# Altium schematic sheet Sheet3.SchDoc
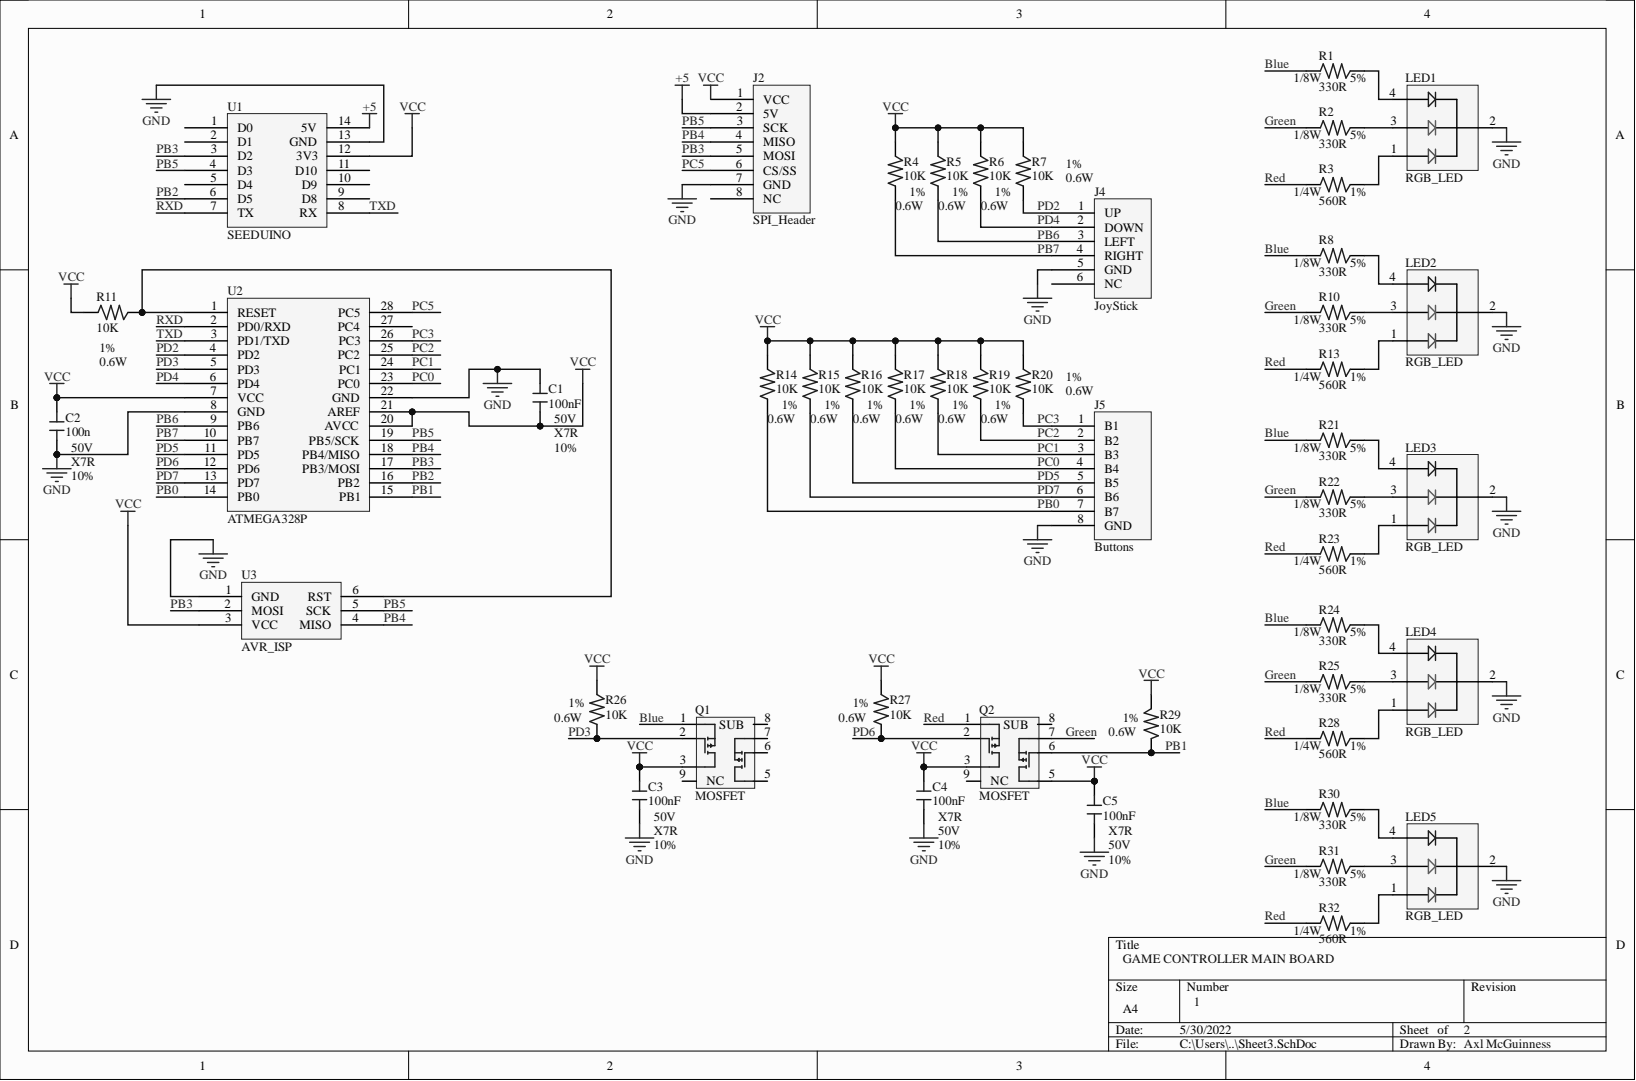
[diagram p0: Sheet3.SchDoc]

## Parts
C1  100nF  Parameter 1: 10%  Parameter 2: 50V  Parameter 3: X7R  [Capacitor]
C2  100n  Parameter 1: 10%  Parameter 2: 50V  Parameter 3: X7R  [Capacitor]
C3  100nF  Parameter 1: 10%  Parameter 2: 50V  Parameter 3: X7R  [Capacitor]
C4  100nF  Parameter 1: 10%  Parameter 2: 50V  Parameter 3: X7R  [Capacitor]
C5  100nF  Parameter 1: 10%  Parameter 2: 50V  Parameter 3: X7R  [Capacitor]
J2  SPI_Header  [8-pin Male]
J4  JoyStick  [6-pin Male]
J5  Buttons  [8-pin Male]
LED1  RGB_LED  [PCBComponent_1]
LED2  RGB_LED  [PCBComponent_1]
LED3  RGB_LED  [PCBComponent_1]
LED4  RGB_LED  [PCBComponent_1]
LED5  RGB_LED  [PCBComponent_1]
Q1  MOSFET  [DIP8]
Q2  MOSFET  [DIP8]
R1  330R  Power Rating: 1/8W  Tolerance: 5%  [Resistor axial]
R2  330R  Power Rating: 1/8W  Tolerance: 5%  [Resistor axial]
R3  560R  Power Rating: 1/4W  Tolerance: 1%  [Resistor axial]
R4  10K  Power Rating: 0.6W  [Resistor axial]
R5  10K  Power Rating: 0.6W  Tolerance: 1%  [Resistor axial]
R6  10K  Power Rating: 0.6W  Tolerance: 1%  [Resistor axial]
R7  10K  Tolerance: 1%  [Resistor axial]
R8  330R  Power Rating: 1/8W  Tolerance: 5%  [Resistor axial]
R10  330R  Power Rating: 1/8W  Tolerance: 5%  [Resistor axial]
R11  10K  Tolerance: 1%  [Resistor axial]
R13  560R  Power Rating: 1/4W  Tolerance: 1%  [Resistor axial]
R14  10K  Power Rating: 0.6W  [Resistor axial]
R15  10K  Power Rating: 0.6W  Tolerance: 1%  [Resistor axial]
R16  10K  Power Rating: 0.6W  Tolerance: 1%  [Resistor axial]
R17  10K  Power Rating: 0.6W  Tolerance: 1%  [Resistor axial]
R18  10K  Power Rating: 0.6W  Tolerance: 1%  [Resistor axial]
R19  10K  Power Rating: 0.6W  Tolerance: 1%  [Resistor axial]
R20  10K  Tolerance: 1%  [Resistor axial]
R21  330R  Power Rating: 1/8W  Tolerance: 5%  [Resistor axial]
R22  330R  Power Rating: 1/8W  Tolerance: 5%  [Resistor axial]
R23  560R  Power Rating: 1/4W  Tolerance: 1%  [Resistor axial]
R24  330R  Power Rating: 1/8W  Tolerance: 5%  [Resistor axial]
R25  330R  Power Rating: 1/8W  Tolerance: 5%  [Resistor axial]
R26  10K  Power Rating: 0.6W  Tolerance: 1%  [Resistor axial]
R27  10K  Power Rating: 0.6W  Tolerance: 1%  [Resistor axial]
R28  560R  Power Rating: 1/4W  Tolerance: 1%  [Resistor axial]
R29  10K  Power Rating: 0.6W  Tolerance: 1%  [Resistor axial]
R30  330R  Power Rating: 1/8W  Tolerance: 5%  [Resistor axial]
R31  330R  Power Rating: 1/8W  Tolerance: 5%  [Resistor axial]
R32  560R  Power Rating: 1/4W  Tolerance: 1%  [Resistor axial]
U1  SEEDUINO  [SEEEDUINO]
U2  ATMEGA328P  [ATMEGA328P]
U3  AVR_ISP  [AVR_ISP]

## Nets
+5: J2.2(5V) U1.14(5V)
GND: C1.2 C2.1 C3.1 C4.1 C5.1 J2.7(GND) J4.5(GND) J5.8(GND) LED1.2 LED2.2 LED3.2 LED4.2 LED5.2 U1.13(GND) U2.8(GND) U2.22(GND) U3.1(GND)
VCC: C1.1 C2.2 C3.2 C4.2 C5.2 J2.1(VCC) Q1.3 Q2.3 Q2.5 R4.2 R5.2 R6.2 R7.2 R11.1 R14.2 R15.2 R16.2 R17.2 R18.2 R19.2 R20.2 R26.2 R27.2 R29.2 U1.12(3V3) U2.7(VCC) U2.20(AVCC) U2.21(AREF) U3.3(VCC)
Blue: Q1.1 R1.1 R8.1 R21.1 R24.1 R30.1
Green: Q2.7 R2.1 R10.1 R22.1 R25.1 R31.1
PB0: J5.7(B7) R14.1 U2.14(PB0)
PB1: Q2.6 R29.1 U2.15(PB1)
PB2: U1.6(D5) U2.16(PB2)
PB3: J2.5(MOSI) U1.3(D2) U2.17(PB3/MOSI) U3.2(MOSI)
PB4: J2.4(MISO) U2.18(PB4/MISO) U3.4(MISO)
PB5: J2.3(SCK) U1.4(D3) U2.19(PB5/SCK) U3.5(SCK)
PB6: J4.3(LEFT) R5.1 U2.9(PB6)
PB7: J4.4(RIGHT) R4.1 U2.10(PB7)
PC0: J5.4(B4) R17.1 U2.23(PC0)
PC1: J5.3(B3) R18.1 U2.24(PC1)
PC2: J5.2(B2) R19.1 U2.25(PC2)
PC3: J5.1(B1) R20.1 U2.26(PC3)
PC5: J2.6(CS/SS) U2.28(PC5)
PD2: J4.1(UP) R7.1 U2.4(PD2)
PD3: Q1.2 R26.1 U2.5(PD3)
PD4: J4.2(DOWN) R6.1 U2.6(PD4)
PD5: J5.5(B5) R16.1 U2.11(PD5)
PD6: Q2.2 R27.1 U2.12(PD6)
PD7: J5.6(B6) R15.1 U2.13(PD7)
Red: Q2.1 R3.1 R13.1 R23.1 R28.1 R32.1
RXD: U1.7(TX) U2.2(PD0/RXD)
TXD: U1.8(RX) U2.3(PD1/TXD)
NetLED1_1: LED1.1 R3.2
NetLED1_3: LED1.3 R2.2
NetLED1_4: LED1.4 R1.2
NetLED2_1: LED2.1 R13.2
NetLED2_3: LED2.3 R10.2
NetLED2_4: LED2.4 R8.2
NetLED3_1: LED3.1 R23.2
NetLED3_3: LED3.3 R22.2
NetLED3_4: LED3.4 R21.2
NetLED4_1: LED4.1 R28.2
NetLED4_3: LED4.3 R25.2
NetLED4_4: LED4.4 R24.2
NetLED5_1: LED5.1 R32.2
NetLED5_3: LED5.3 R31.2
NetLED5_4: LED5.4 R30.2
NetR11_2: R11.2 U2.1(RESET) U3.6(RST)

Not connected: J2 8(NC); J4 6(NC); Q1 5 6 7 8(SUB) 9(NC); Q2 8(SUB) 9(NC); U1 1(D0) 2(D1) 5(D4) 9(D8) 10(D9) 11(D10); U2 27(PC4)

## Library data of the parts
C1-C5 = Capacitor: Component Kind: Standard; Library Name: Schlib1.SchLib
R1-R3 R5 R6 R8 R10 R13 R15-R19 R21-R32 = Resistor: Component Kind: Standard; Library Name: Schlib1.SchLib
R4 R14 = Resistor: Tolerance: 1%; Component Kind: Standard; Library Name: Schlib1.SchLib
R7 R11 R20 = Resistor: Power Rating: 0.6W; Component Kind: Standard; Library Name: Schlib1.SchLib
J2 = SPI_Header: Component Kind: Standard; Library Name: Schlib1.SchLib
J4 = JoyStick: Component Kind: Standard; Library Name: Schlib1.SchLib
J5 = Buttons: Component Kind: Standard; Library Name: Schlib1.SchLib
LED1-LED5 = RGB_LED: Component Kind: Standard; Library Name: Schlib1.SchLib
Q1 Q2 = MOSFET: Component Kind: Standard; Library Name: Schlib1.SchLib
U1 = SEEDUINO: Component Kind: Standard; Library Name: Schlib1.SchLib
U2 = ATMEGA328P: Component Kind: Standard; Library Name: Schlib1.SchLib
U3 = AVR_ISP: Component Kind: Standard; Library Name: Schlib1.SchLib
